# Altium schematic sheet Sheet5.SchDoc
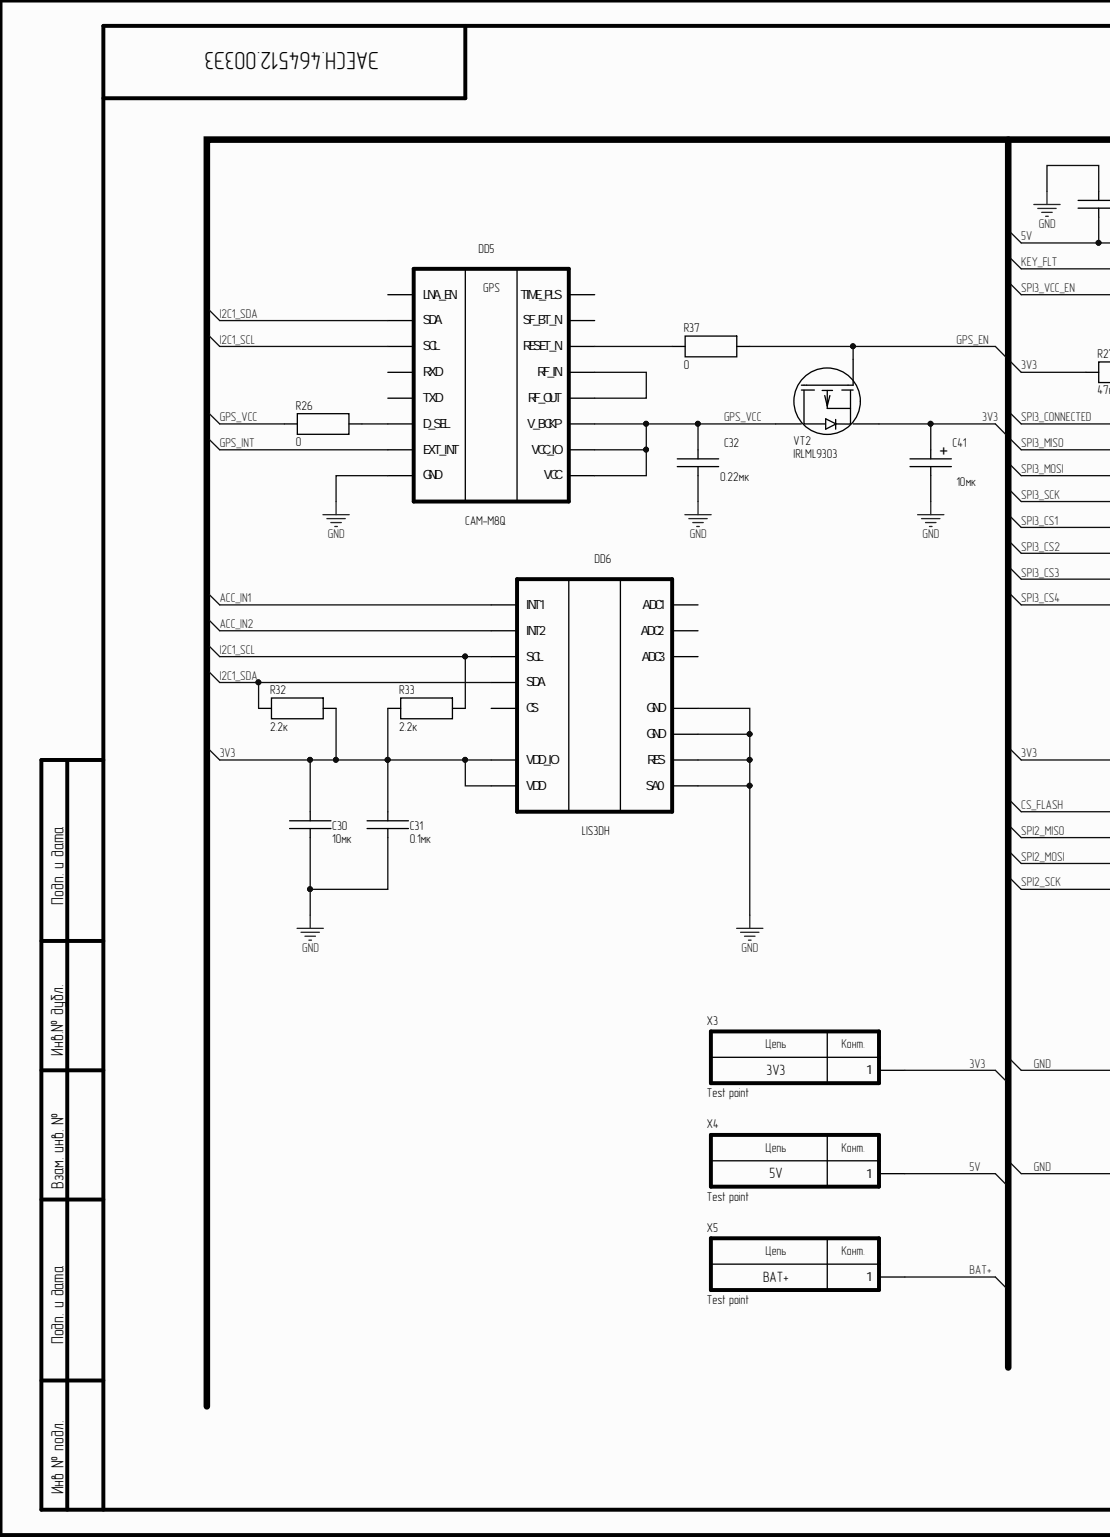
[diagram p0: Sheet5.SchDoc, left]
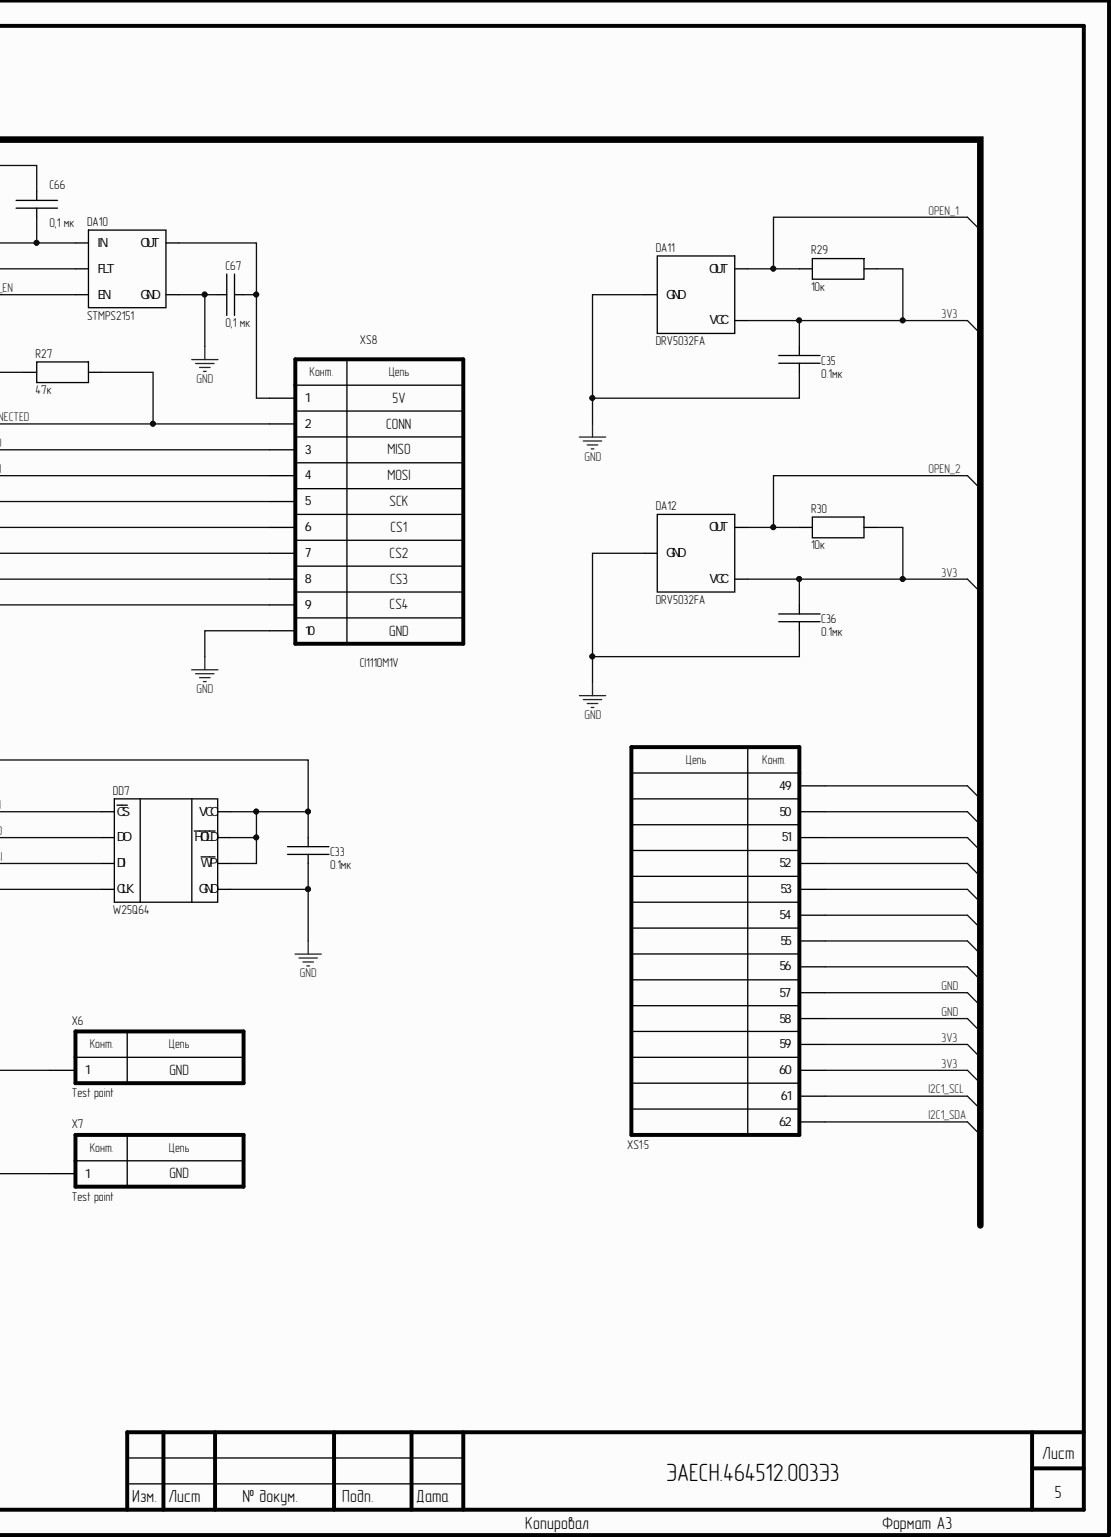
[diagram p1: Sheet5.SchDoc, right]

## Parts
C30  value 10мк  [С0603]  (hidden comment: 100n)
C31  C  value 0.1мк  [С0603]
C32  C  value 0.22мк  [С0603]
C33  C  value 0.1мк  [С0603]
C35  C  value 0.1мк  [С0603]
C36  C  value 0.1мк  [С0603]
C41  value 10мк  [Case A]  (hidden comment: C_el)
C66  C  value 0,1 мк  [С0603]
C67  C  value 0,1 мк  [С0603]
DA10  STMPS2151  [SOT-23-5]
DA11  DRV5032FA  [SOT-23]
DA12  DRV5032FA  [SOT-23]
DD5  CAM-M8Q  [CAM-M8Q]
DD6  LIS3DH  [LGA-16]
DD7  W25Q64  [SOIC8]
R26  R  value 0  [R0603]
R27  R  value 47к  [R0603]
R29  R  value 10к  [R0603]
R30  R  value 10к  [R0603]
R32  R  value 2.2к  [R0603]
R33  R  value 2.2к  [R0603]
R37  R  value 0  [R0603]
VT2  IRLML9303  [SOT-23]
X3  Test point  [Test_point]
X4  Test point  [Test_point]
X5  Test point  [Test_point]
X6  Test point  [Test_point]
X7  Test point  [Test_point]
XS1  M.2  [M.2_POE]  (value not drawn)  (part :5)
XS8  СI1110М1V  [СI1110М1V]

## Nets
3V3: C30.1 C31.1 C33.1 C35.1 C36.1 C41.1 DA11.1 DA12.1 DD6.1(VDD_IO) DD6.14(VDD) DD7.3(WP) DD7.7(HOLD) DD7.8(VCC) R27.1 R29.2 R30.2 R32.2 R33.1 VT2.2 X3.1 XS1.59 XS1.60
5V: C66.2 DA10.5(IN) X4.1
ACC_IN1: DD6.11(INT1)
ACC_IN2: DD6.9(INT2)
BAT+: X5.1
CS_FLASH: DD7.1(CS)
GND: C30.2 C31.2 C32.2 C33.2 C35.2 C36.2 C41.2 C66.1 C67.1 DA10.2(GND) DA11.3 DA12.3 DD5.4(GND) DD5.5 DD5.10 DD5.11 DD5.12 DD5.13 DD5.14 DD5.15 DD5.18 DD5.19 DD5.21 DD5.22 DD5.27 DD5.31 DD6.5(GND) DD6.7(SA0) DD6.10(RES) DD6.12(GND) DD7.4(GND) X6.1 X7.1 XS1.57 XS1.58 XS8.10
GPS_EN: R37.2 VT2.1
GPS_INT: DD5.7(EXT_INT)
GPS_VCC: C32.1 DD5.1(VCC_IO) DD5.8(V_BCKP) DD5.9(VCC) R26.2 VT2.3
I2C1_SCL: DD5.6(SCL) DD6.4(SCL) R33.2 XS1.61
I2C1_SDA: DD5.3(SDA) DD6.6(SDA) R32.1 XS1.62
KEY_FLT: DA10.3(FLT)
OPEN_1: DA11.2 R29.1
OPEN_2: DA12.2 R30.1
SPI2_MISO: DD7.2(DO)
SPI2_MOSI: DD7.5(DI)
SPI2_SCK: DD7.6(CLK)
SPI3_CONNECTED: R27.2 XS8.2
SPI3_CS1: XS8.6
SPI3_CS2: XS8.7
SPI3_CS3: XS8.8
SPI3_CS4: XS8.9
SPI3_MISO: XS8.3
SPI3_MOSI: XS8.4
SPI3_SCK: XS8.5
SPI3_VCC_EN: DA10.4(EN)
NetC67_2: C67.2 DA10.1(OUT) XS8.1
NetDD5_16: DD5.16(RF_OUT) DD5.17(RF_IN)
NetDD5_20: DD5.20(D_SEL) R26.1
NetDD5_23: DD5.23(RESET_N) R37.1

Not connected: DD5 24(SF_BT_N) 25(TXD) 26(RXD) 29(TIME_PLS) 30(LNA_EN); DD6 8(CS) 13(ADC3) 15(ADC2) 16(ADC1); XS1 49 50 51 52 53 54 55 56

## Library data of the parts
C30 = C: Component Kind: Standard; Library Name: Schlib1.SchLib
C66 = C: Value: 0,1 мк; Component Kind: Standard; Library Name: Schlib1.SchLib
DA10 = STMPS21x1: Component Kind: Standard; Library Name: Schlib1.SchLib
DA11 DA12 = DRV5032: Component Kind: Standard; Library Name: Schlib1.SchLib
DD5 = CAM-M8Q: GPS; Component Kind: Standard; Library Name: Schlib1.SchLib
DD6 = LIS3DH: Accelerometr; Component Kind: Standard; Library Name: Schlib1.SchLib
DD7 = W25Q64: RAM; Component Kind: Standard; Library Name: Schlib1.SchLib
VT2 = P-channel: Component Kind: Standard; Library Name: Schlib1.SchLib
X3-X7 = Test_point: Component Kind: Standard; Library Name: Schlib1.SchLib
XS8 = 10pin: Component Kind: Standard; Library Name: Schlib1.SchLib
C31-C33 C35 C36 C67 = C: Component Kind: Standard; Library Name: Schlib1.SchLib
C41 = C_el: Component Kind: Standard; Library Name: Schlib1.SchLib
R26 R27 R29 R30 R32 R33 R37 = R: Component Kind: Standard; Library Name: Schlib1.SchLib
XS1 = M.2: Component Kind: Standard; Library Name: Schlib1.SchLib
